# Altium schematic sheet PC Communication.SchDoc("PC Communication")
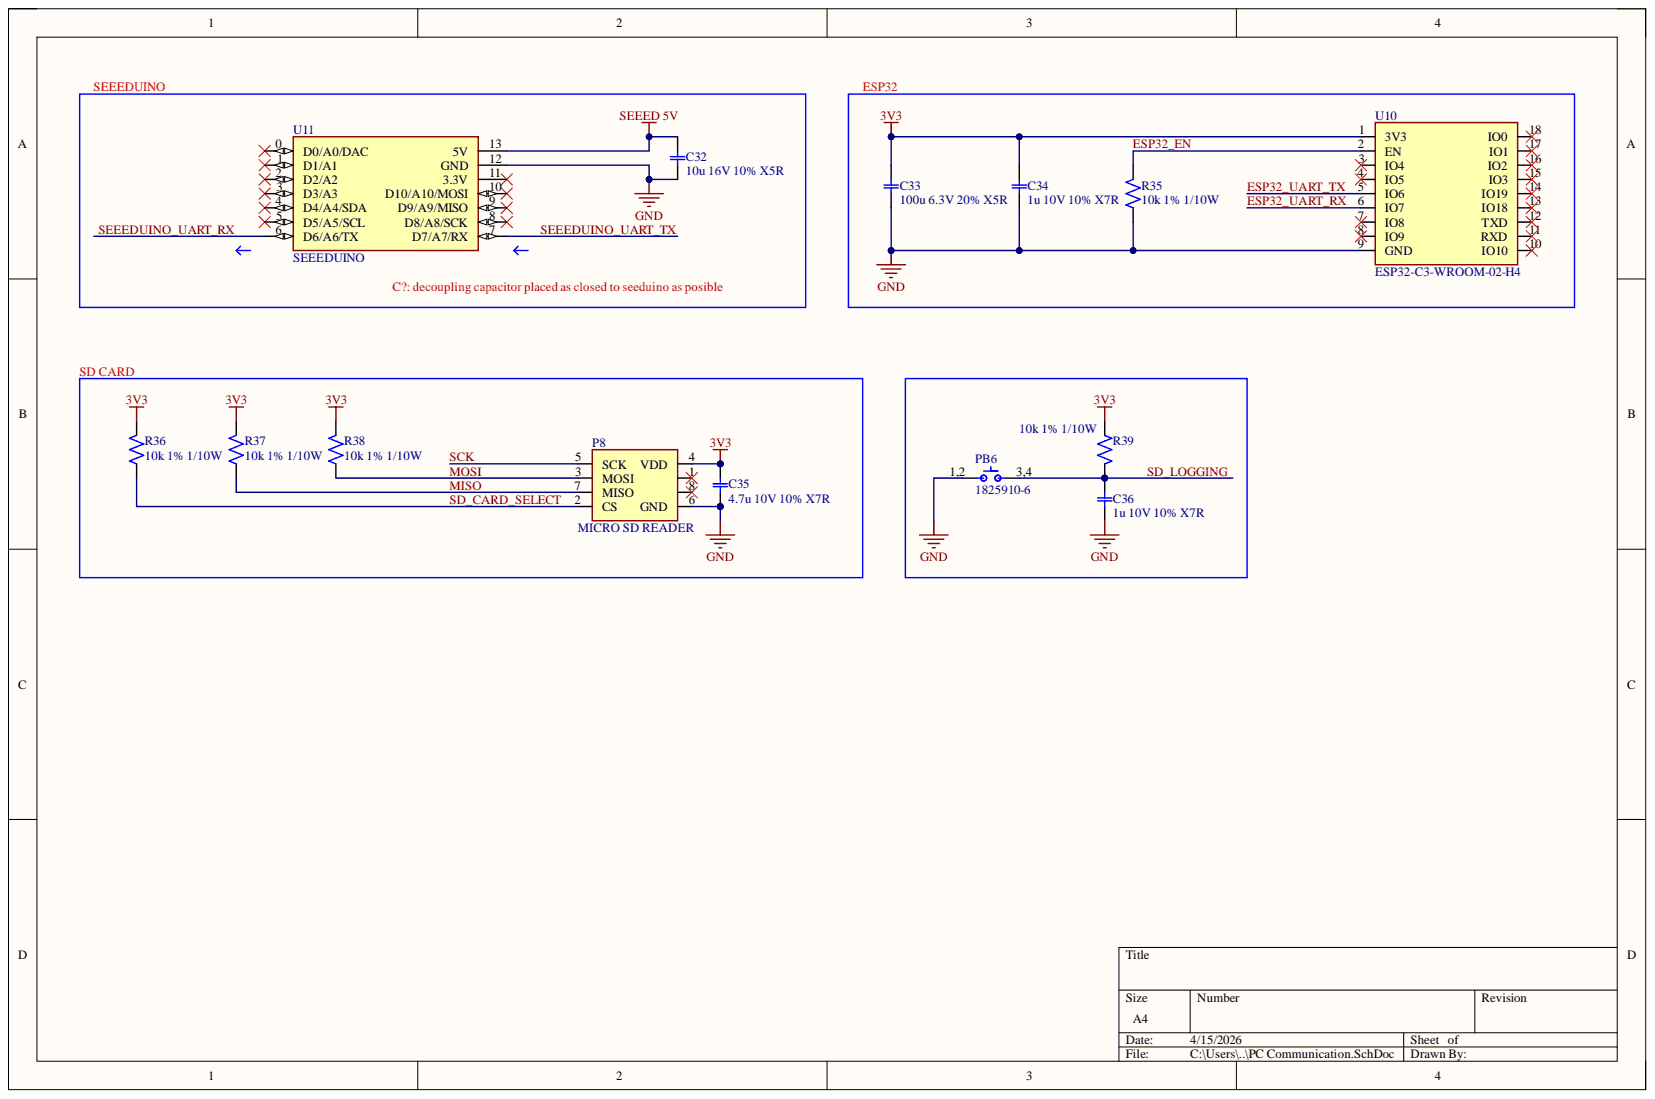
[diagram p0: PC Communication.SchDoc]

## Parts
C32  10u 16V 10% X5R  Voltage: 16V  [0805-C]
C33  100u 6.3V 20% X5R  [1206 - C]
C34  1u 10V 10% X7R  Voltage Rating: 10V  [0603-C]
C35  4.7u 10V 10% X7R  [0603-C]
C36  1u 10V 10% X7R  Voltage Rating: 10V  [0603-C]
P8  MICRO SD READER  [MICRO SD READER]
PB6  1825910-6  [1825910-6]
R35  10k 1% 1/10W  Resistance Tolerance: 1%  [0603-R]
R36  10k 1% 1/10W  Resistance Tolerance: 1%  [0603-R]
R37  10k 1% 1/10W  Resistance Tolerance: 1%  [0603-R]
R38  10k 1% 1/10W  Resistance Tolerance: 1%  [0603-R]
R39  10k 1% 1/10W  [0603-R]
U10  ESP32-C3-WROOM-02-H4  [ESP32 surface mount]
U11  SEEEDUINO  [XIAO SAMD21]

## Nets
3V3: C33.1 C34.1 C35.1 P8.4(VDD) R36.1 R37.1 R38.1 R39.2 U10.1(3V3)
GND: C32.2 C33.2 C34.2 C35.2 C36.2 P8.6(GND) PB6.1,2 R35.2 U10.9(GND) U11.12(GND)
SEEED 5V: C32.1 U11.13(5V)
ESP32_EN: R35.1 U10.2(EN)
ESP32_UART_RX: U10.6(IO7)
ESP32_UART_TX: U10.5(IO6)
MISO: P8.7(MISO) R37.2
MOSI: P8.3(MOSI) R38.2
SCK: P8.5(SCK)
SD_CARD_SELECT: P8.2(CS) R36.2
SD_LOGGING: C36.1 PB6.3,4 R39.1
SEEEDUINO_UART_RX: U11.6(D6/A6/TX)
SEEEDUINO_UART_TX: U11.7(D7/A7/RX)

Not connected: P8 1 8; U10 3(IO4) 4(IO5) 7(IO8) 8(IO9) 10(IO10) 11(RXD) 12(TXD) 13(IO18) 14(IO19) 15(IO3) 16(IO2) 17(IO1) 18(IO0); U11 0(D0/A0/DAC) 1(D1/A1) 2(D2/A2) 3(D3/A3) 4(D4/A4/SDA) 5(D5/A5/SCL) 8(D8/A8/SCK) 9(D9/A9/MISO) 10(D10/A10/MOSI) 11(3.3V)

## Library data of the parts
C32 = GRM21BR61C106KE15K: Multilayer Ceramic Capacitor, 10 uF, 16 V, ï¿½ 10%, X5R, 0805 [2012 Metric]; Manufacturer: Murata; Value: 10uF; Case Code (Imperial): 0805; Case Code (Metric): 2012; Case/Package: 0805; Category: Ceramic Capacitors; Component Kind: Standard; ComponentLink1Description: https://datasheet.ciiva.com/6270/partnumbering-e-01-6270407.pdf?src-supplier=Digikey; ComponentLink1URL: https://datasheet.ciiva.com/6270/partnumbering-e-01-6270407.pdf?src-supplier=Digikey; ComponentLink2Description: https://datasheet.ciiva.com/6270/partnumbering-e-01-6270410.pdf?src-supplier=Digikey; ComponentLink2URL: https://datasheet.ciiva.com/6270/partnumbering-e-01-6270410.pdf?src-supplier=Digikey; ComponentLink3Description: https://datasheet.ciiva.com/6270/partnumbering-e-01-6270412.pdf?src-supplier=Digikey; ComponentLink3URL: https://datasheet.ciiva.com/6270/partnumbering-e-01-6270412.pdf?src-supplier=Digikey; ComponentLink4Description: https://datasheet.ciiva.com/5414/product-5414934.pdf?src-supplier=Mouser; ComponentLink4URL: https://datasheet.ciiva.com/5414/product-5414934.pdf?src-supplier=Mouser; Construction: Flat; Depth: 1.25mm; Dielectric: X5R; Height: 1.25mm; Length: 2mm; Manufacturer Part Number: GRM21BR61C106KE15K; Material: Ceramic; Max Operating Temperature: 85°C; Min Operating Temperature: -55°C; Mounting Technology: Surface Mount; Package Quantity: 1; Packaging: Tape and Reel; Pricing: 1=0.14, 10=0.081, 100=0.0497, 1000=0.03306, 2500=0.0261 (USD); Radiation Hardening: No; Reach SVHC Compliant: No; RoHS: Yes; RoHS Compliant: Yes; Series: GRM; Stock: 876269; Supplier 1: Digikey; Supplier Part Number 1: 490-6473-1-ND; Termination: SMD/SMT; Thickness: 0.053inch; Tolerance: 10%; Voltage Rating: 16V; Voltage Rating (DC): 16V; Width: 0.049inch; Library Name: TP_Draft.SchLib
C33 = JMK316ABJ107ML-T: Multilayer Ceramic Capacitors MLCC - SMD/SMT 100uF 6.3Volts X5R +/-20% 1206 HI CV; Manufacturer: Taiyo Yuden; Material: 4241ConductorMaterial; Case Code (Imperial): 1206; Case Code (Metric): 3216; Case/Package: 1206; Category: Ceramic Capacitors; Component Kind: Standard; ComponentLink1Description: https://datasheet.ciiva.com/18697/mlcc-all-e-18697768.pdf?src-supplier=Digikey; ComponentLink1URL: https://datasheet.ciiva.com/18697/mlcc-all-e-18697768.pdf?src-supplier=Digikey; ComponentLink2Description: https://datasheet.ciiva.com/18697/mlcc-all-e-18697769.pdf?src-supplier=Digikey; ComponentLink2URL: https://datasheet.ciiva.com/18697/mlcc-all-e-18697769.pdf?src-supplier=Digikey; ComponentLink3Description: https://datasheet.ciiva.com/18697/mlcc-all-e-18697770.pdf?src-supplier=Digikey; ComponentLink3URL: https://datasheet.ciiva.com/18697/mlcc-all-e-18697770.pdf?src-supplier=Digikey; ComponentLink4Description: https://datasheet.ciiva.com/30253/255jmk316abj107ml-t-30253356.pdf?src-supplier=Onlinecomponents.com; ComponentLink4URL: https://datasheet.ciiva.com/30253/255jmk316abj107ml-t-30253356.pdf?src-supplier=Onlinecomponents.com; Construction: Flat; Depth: 1.6mm; Dielectric: X5R; Height: 1.6mm; Length: 3.2mm; Manufacturer Part Number: JMK316ABJ107ML-T; Max Operating Temperature: 85°C; Min Operating Temperature: -55°C; Mounting Technology: Surface Mount; Package Quantity: 1; Packaging: Tape and Reel; Pricing: 1=0.46, 10=0.278, 50=0.2074, 100=0.1857, 500=0.15246, 1000=0.13767 (USD); RoHS: Yes; RoHS Compliant: Yes; Series: M; Stock: 280941; Supplier 1: Digikey; Supplier Part Number 1: 587-3395-1-ND; Termination: SMD/SMT; Thickness: 0.071inch; Tolerance: 20%; Value: 100uF; Voltage: 6.3V; Voltage Rating: 6.3V; Voltage Rating (DC): 6.3V; Width: 0.063inch; Library Name: tpmania.SchLib
C34 C36 = CC0603KRX7R6BB105: 0603 1 uF 10 V ±10 % Tolerance X7R SMT Multilayer Ceramic Capacitor; Manufacturer: Yageo; Value: 1uF; Case Code (Imperial): 0603; Case Code (Metric): 1608; Case/Package: 0603; Category: Ceramic Capacitors; Component Kind: Standard; ComponentLink1Description: https://datasheet.ciiva.com/26862/getdatasheetpartid-403568-26862819.pdf?src-supplier=Verical; ComponentLink1URL: https://datasheet.ciiva.com/26862/getdatasheetpartid-403568-26862819.pdf?src-supplier=Verical; ComponentLink2Description: https://datasheet.ciiva.com/13208/upy-gphc-x7r-6-3v-to-50v-8-12304-13208872.pdf?src-supplier=Mouser; ComponentLink2URL: https://datasheet.ciiva.com/13208/upy-gphc-x7r-6-3v-to-50v-8-12304-13208872.pdf?src-supplier=Mouser; Depth: 0.8mm; Dielectric: X7R; Halogen Free: 5694HalogenFree; Lead Free: Lead Free; Length: 1.6mm; Manufacturer Part Number: CC0603KRX7R6BB105; Material: Ceramic; Max Operating Temperature: 125°C; Min Operating Temperature: -55°C; Mounting Technology: Surface Mount; Packaging: Tape and Reel; Pricing: 1=0.13, 10=0.072, 100=0.0434, 1000=0.02889 (USD); Radiation Hardening: No; RoHS: Yes; RoHS Compliant: Yes; Series: CC; Stock: 262345; Supplier 1: Digikey; Supplier Part Number 1: 311-1796-1-ND; Termination: SMD/SMT; Tolerance: 10%; Voltage Rating (DC): 10V; Weight: 7.1E-05oz; Width: 0.8mm; Library Name: tpmania.SchLib
C35 = GMC10X7R475K10NT: Ceramic Capacitor, Multilayer, Ceramic, 10V, 10% +Tol, 10% -Tol, X7R, 15% TC, 4.7uF, Surface Mount, 0603; Manufacturer: Cal-Chip Electronics; Value: 4.7µF; Category: Ceramic Capacitors; China RoHS: Compliant; Component Kind: Standard; ComponentLink1Description: https://datasheet.octopart.com:443/GMC10X7R475K10NT-Cal-Chip-Electronics-datasheet-182028815.pdf?src-supplier=IHS; ComponentLink1URL: https://datasheet.octopart.com:443/GMC10X7R475K10NT-Cal-Chip-Electronics-datasheet-182028815.pdf?src-supplier=IHS; Dielectric Material: Ceramic; Height: 900µm; Length: 1.6mm; Lifecycle Status: Production; Manufacturer Part Number: GMC10X7R475K10NT; Max Operating Temperature: 125°C; Min Operating Temperature: -55°C; Mounting Technology: Surface Mount; Number of Terminals: 2; Pricing: 1=0.26, 10=0.154, 50=0.1112, 100=0.0982, 500=0.07628, 1000=0.06951 (USD); REACH SVHC: Yes; RoHS: Compliant; Stock: 238375; Supplier 1: DigiKey; Supplier Part Number 1: 4713-GMC10X7R475K10NTCT-ND; Voltage Rating (DC): 10V; Width: 800µm; Library Name: tpmania.SchLib
P8 = Micro SD Reader: Micro SD Card Reader; Component Kind: Standard; Library Name: ENGG3800 schematic library.SchLib; Supplier 1: etsg; Supplier Part Number: 08-70-02
PB6 = 1825910-6: Switch Tactile OFF (ON) SPST Round Button PC Pins 0.05A 24VDC 1.57N Thru-Hole Loose; Manufacturer: TE Connectivity Alcoswitch; Actuator Length: 0.055inch; Actuator Material: PBT; Actuator Type: Standard; Contact Current Rating: 50mA; Illumination Type: Non-Illuminated,Illuminated; Insulation Resistance: 100MR; Max Voltage Rating (DC): 24V; Mechanical Life: 100000Cycles; Number of Positions: 2NUMBER; Operating Force: 160gf; Switch Function: Off-Mom; Switch Travel: 0.25mm; Category: Pushbutton Switches; Color: 46Colour; Component Kind: Standard; ComponentLink1Description: https://datasheet.ciiva.com/30199/1825910-6rqpn1825910-6-30199520.pdf?src-supplier=Onlinecomponents.com; ComponentLink1URL: https://datasheet.ciiva.com/30199/1825910-6rqpn1825910-6-30199520.pdf?src-supplier=Onlinecomponents.com; ComponentLink2Description: https://datasheet.ciiva.com/15184/getdatasheetpartid-395915-15184509.pdf?src-supplier=Verical; ComponentLink2URL: https://datasheet.ciiva.com/15184/getdatasheetpartid-395915-15184509.pdf?src-supplier=Verical; Contact Material: Copper Alloy; Contact Plating: Silver; Current Rating: 50mA; ELV: 5681ELVCompliant; Lead Free: 5697LeadFree; Length: 5.99mm; Manufacturer Part Number: 1825910-6; Material: 4261ConductorMaterial; Max Current Rating: 50mA; Max Operating Temperature: 85°C; Min Operating Temperature: -35°C; Mounting Technology: Through Hole; Packaging: Loose Piece; Pricing: 1=0.06097, 10=0.05768, 30=0.05616, 50=0.05548, 100=0.05519 (USD); Reach SVHC Compliant: Unknown; RoHS: Yes; RoHS Compliant: Yes; Series: FSMJ; Stock: 140583; Supplier 1: Avnet; Supplier Part Number 1: 1825910-6; Termination: PC Pins; Throw Configuration: SPST; Type: Switch; Width: 6mm; Library Name: tpmania.SchLib
R35-R39 = RK73H1JTTD1002F: Resistor Thick Film 0603 10K Ohm 1% 1/10W ±100ppm/°C SMD Punched Paper Tape and Reel; Manufacturer: KOA Speer; Max Power Dissipation: 100mW; Value: 10kR; Voltage Rating: 50V; Case Code (Imperial): 0603; Case Code (Metric): 1608; Case/Package: 0603; Category: Chip SMD Resistors; Component Kind: Standard; ComponentLink1Description: https://datasheet.ciiva.com/5556/rk73h-5556565.pdf?src-supplier=Mouser; ComponentLink1URL: https://datasheet.ciiva.com/5556/rk73h-5556565.pdf?src-supplier=Mouser; ComponentLink2Description: https://datasheet.ciiva.com/48426/rk73h-series-eng-jap-tds-48426520.pdf?src-supplier=Distrelec; ComponentLink2URL: https://datasheet.ciiva.com/48426/rk73h-series-eng-jap-tds-48426520.pdf?src-supplier=Distrelec; ComponentLink3Description: https://datasheet.ciiva.com/7773/549017-7773368.pdf?src-supplier=Element14; ComponentLink3URL: https://datasheet.ciiva.com/7773/549017-7773368.pdf?src-supplier=Element14; ComponentLink4Description: https://datasheet.ciiva.com/15159/getdatasheetpartid-89857-15159884.pdf?src-supplier=Verical; ComponentLink4URL: https://datasheet.ciiva.com/15159/getdatasheetpartid-89857-15159884.pdf?src-supplier=Verical; Construction: Rectangular; Depth: 0.8mm; Features: Moisture Resistant,Automotive AEC-Q200; Height: 0.45mm; Lead Free: Lead Free; Length: 1.6mm; Manufacturer Part Number: RK73H1JTTD1002F; Max Operating Temperature: 155°C; Military Standard: Not; Min Operating Temperature: -55°C; Number of Terminations: 2; Package Quantity: 5000; Packaging: Tape and Reel; Pins: 2; Power Rating: 100mW; Pricing: 1=0.1, 10=0.026, 100=0.0124, 1000=0.0068, 2500=0.00557 (USD); Ratings: AEC-Q200; Reach SVHC Compliant: Unknown; Resistor Type: Thick Film; RoHS: Yes; RoHS Compliant: Yes; Series: RK73H; Stock: 1507817; Supplier 1: Digikey; Supplier Part Number 1: 2019-RK73H1JTTD1002FCT-ND; Temperature Coefficient: 100ppm/°C; Termination: SMD/SMT; Tolerance: 1%; Voltage Rating (DC): 50V; Width: 0.8mm; Library Name: tpmania.SchLib
  R39: Resistance Tolerance: 1%
U10 = ESP32-C3-WROOM-02-H4: WiFi-Module 802.11 2, 4GHz 150Mb/s; Manufacturer: Espressif Systems; Category: RF Transceiver Modules and Modems; Component Kind: Standard; ComponentLink1Description: https://datasheet.octopart.com:443/ESP32-C3-WROOM-02-H4-Espressif-Systems-datasheet-188867343.pdf?src-supplier=TME; ComponentLink1URL: https://datasheet.octopart.com:443/ESP32-C3-WROOM-02-H4-Espressif-Systems-datasheet-188867343.pdf?src-supplier=TME; ComponentLink2Description: https://datasheet.octopart.com:443/ESP32-C3-WROOM-02-H4-Espressif-Systems-datasheet-165560559.pdf?src-supplier=TME; ComponentLink2URL: https://datasheet.octopart.com:443/ESP32-C3-WROOM-02-H4-Espressif-Systems-datasheet-165560559.pdf?src-supplier=TME; ComponentLink3Description: https://datasheet.octopart.com:443/ESP32-C3-WROOM-02-H4-Espressif-Systems-datasheet-156944166.pdf?src-supplier=TME; ComponentLink3URL: https://datasheet.octopart.com:443/ESP32-C3-WROOM-02-H4-Espressif-Systems-datasheet-156944166.pdf?src-supplier=TME; ComponentLink4Description: https://datasheet.octopart.com:443/ESP32-C3-WROOM-02-H4-Espressif-Systems-datasheet-156947392.pdf?src-supplier=TME; ComponentLink4URL: https://datasheet.octopart.com:443/ESP32-C3-WROOM-02-H4-Espressif-Systems-datasheet-156947392.pdf?src-supplier=TME; Library Name: ENGG3800 schematic library.SchLib; Manufacturer Part Number: ESP32-C3-WROOM-02-H4; Pricing: 1=3.51, 10=3.032, 25=2.866, 100=2.6371, 250=2.49988 (USD); Stock: 1965; Supplier 1: DigiKey; Supplier Part Number 1: 1965-ESP32-C3-WROOM-02-H4CT-ND
U11 = 102010328: SAMD21 Microcontroller Development Board 0.0327MHz/48MHzCPU 32KB RAM 256KB Flash; Manufacturer: Seeed Studio; Core Architecture: ARM; Evaluation Kit: Yes; Category: DSPs - Digital Signal Processors; Component Kind: Standard; ComponentLink1Description: https://media.digikey.com/pdf/Data%20Sheets/Seeed%20Technology/102010328_Web.pdf?src-supplier=Digikey; ComponentLink1URL: https://media.digikey.com/pdf/Data%20Sheets/Seeed%20Technology/102010328_Web.pdf?src-supplier=Digikey; Manufacturer Part Number: 102010328; Pricing: 1=5.4 (USD); RoHS: No; Series: SAM D; Stock: 1202; Supplier 1: Digikey; Supplier Part Number 1: 1597-102010328-ND; Library Name: tpmania.SchLib
